# Altium schematic sheet FPGA_POWER_AND_CONFIGURATION.SchDoc
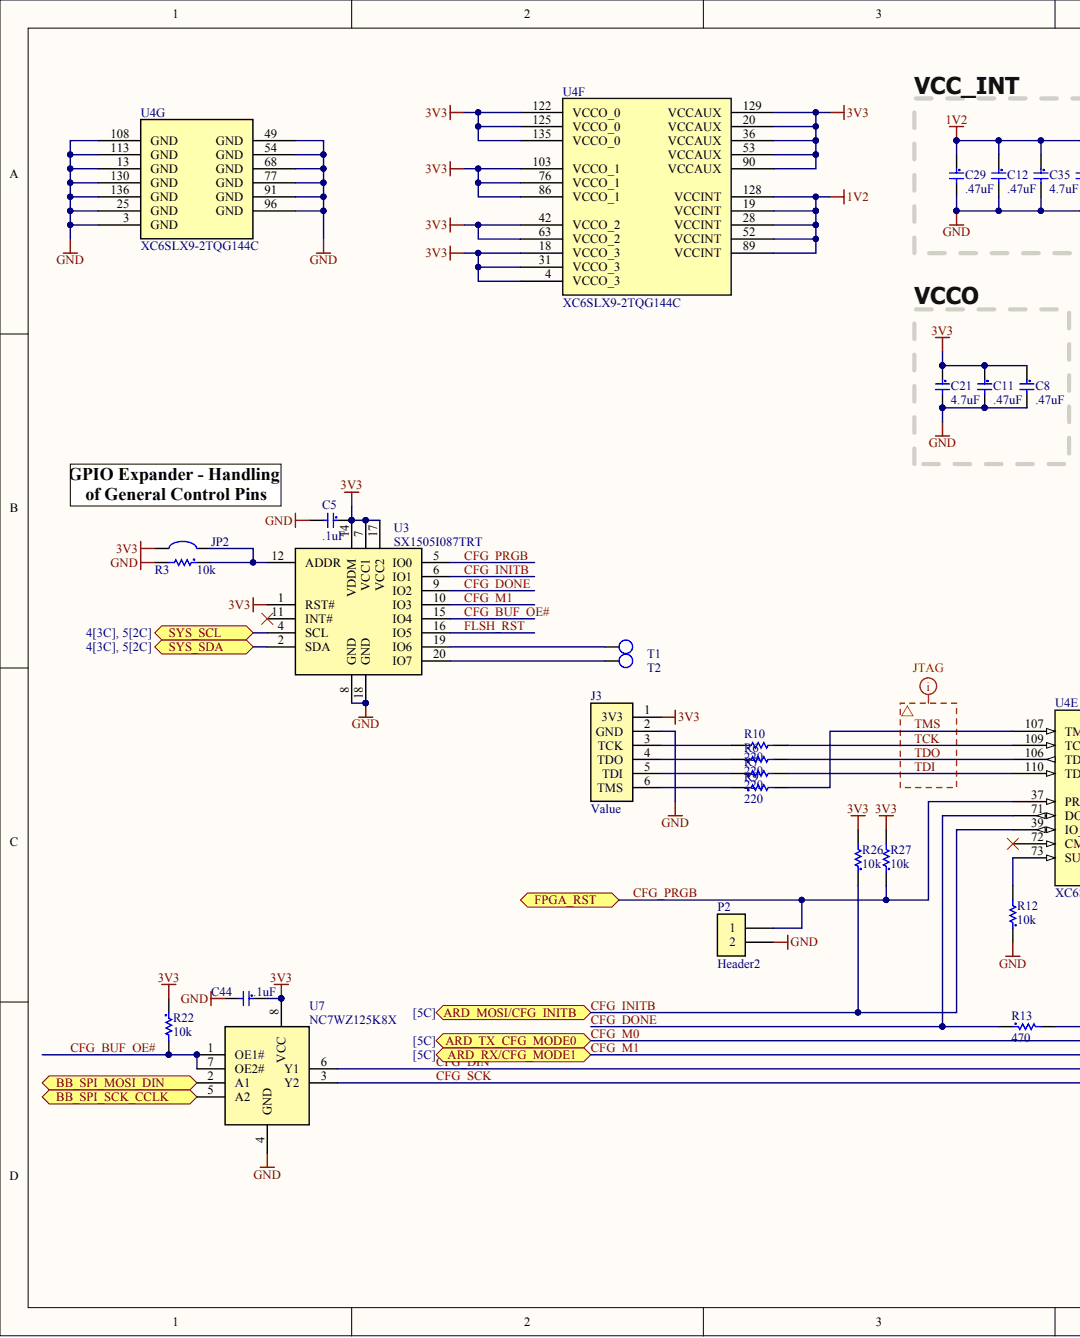
[diagram p0: FPGA_POWER_AND_CONFIGURATION.SchDoc, left]
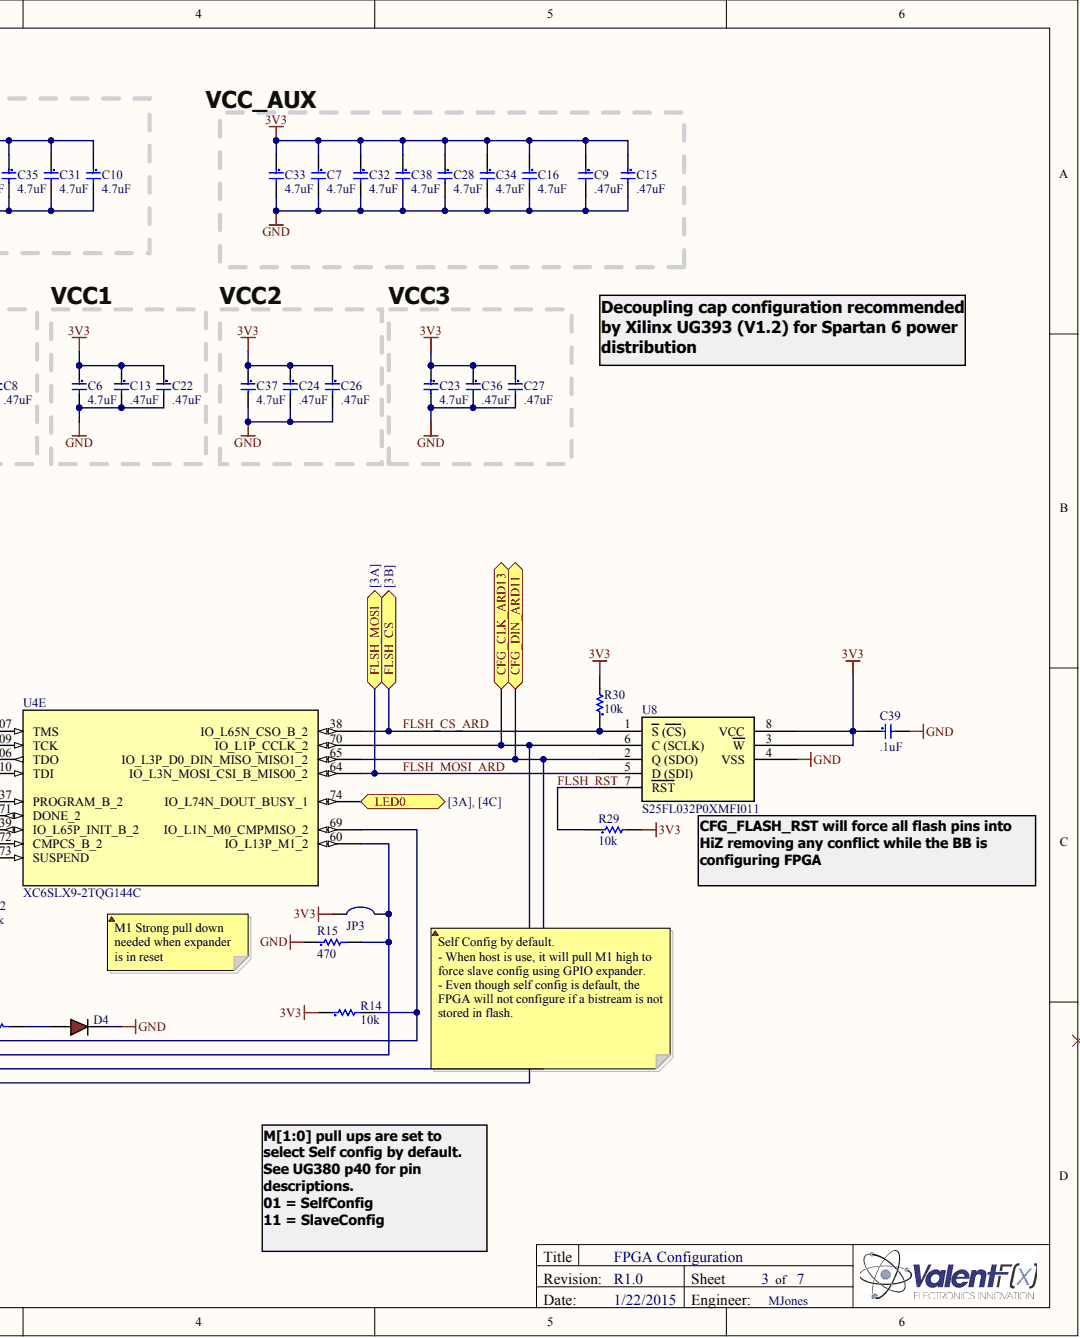
[diagram p1: FPGA_POWER_AND_CONFIGURATION.SchDoc, right]

## Parts
C5  .1uF  [c0603]
C6  4.7uF  [C0603 - Marked]
C7  4.7uF  [C0603 - Marked]
C8  .47uF  [C0603]
C9  .47uF  [C0603]
C10  4.7uF  [C0603 - Marked]
C11  .47uF  [C0603]
C12  .47uF  [C0603]
C13  .47uF  [C0603]
C15  .47uF  [C0603]
C16  4.7uF  [C0603 - Marked]
C21  4.7uF  [C0603 - Marked]
C22  .47uF  [C0603]
C23  4.7uF  [C0603 - Marked]
C24  .47uF  [C0603]
C26  .47uF  [C0603]
C27  .47uF  [C0603]
C28  4.7uF  [C0603 - Marked]
C29  .47uF  [C0603]
C31  4.7uF  [C0603 - Marked]
C32  4.7uF  [C0603 - Marked]
C33  4.7uF  [C0603 - Marked]
C34  4.7uF  [C0603 - Marked]
C35  4.7uF  [C0603 - Marked]
C36  .47uF  [C0603]
C37  4.7uF  [C0603 - Marked]
C38  4.7uF  [C0603 - Marked]
C39  .1uF  [c0603]
C44  .1uF  [c0603]
D4  598-8010-107F  [LED_0603 - RED]  (value not drawn)
J3  Value  [JTAG - Digilent HS1 RA]  (no BOM)
JP2  Solder Jumper  [SOLDER_JUMPER]  (value not drawn)
JP3  Solder Jumper  [SOLDER_JUMPER]  (value not drawn)  (no BOM)
P2  Header2  [HEADER_2_40_100 THRU SIP]  (no BOM)
R3  10k  [R0603]
R6  220  [R0603]
R7  220  [R0603]
R9  220  [R0603]
R10  220  [R0603]
R12  10k  [R0603]
R13  470  [R0603]
R14  10k  [R0603]
R15  470  [R0603]
R22  10k  [R0603]
R26  10k  [R0603]
R27  10k  [R0603]
R29  10k  [R0603]
R30  10k  [R0603]
T1  Test Point  [Tp 13-27]  (value not drawn)  (no BOM)
T2  Test Point  [Tp 13-27]  (value not drawn)  (no BOM)
U3  SX1505I087TRT  [SX1504 - QFN40P300X300X2_HS-21L]
U4  XC6SLX9-2TQG144C  [TQ144_L]  (parts E,F,G)
U7  NC7WZ125K8X  [NC7WZ125K8X - TSSOP50P310X80-8L]
U8  S25FL032P0XMFI011  [S25FL032P0XMFI011 - SOIC127P800X216-8L]

## Ports
ARD_MOSI/CFG_INITB, ARD_RX/CFG_MODE1, ARD_TX_CFG_MODE0, BB_SPI_MOSI_DIN, BB_SPI_SCK_CCLK, CFG_CLK_ARD13, CFG_DIN_ARD11, FLSH_CS, FLSH_MOSI, FPGA_RST, LED0, SYS_SCL, SYS_SDA

## Nets
1V2: C10.1 C12.1 C29.1 C31.1 C35.1 U4.19(VCCINT) U4.28(VCCINT) U4.52(VCCINT) U4.89(VCCINT) U4.128(VCCINT)
3V3: C5.1 C6.1 C7.1 C8.1 C9.1 C11.1 C13.1 C15.1 C16.1 C21.1 C22.1 C23.1 C24.1 C26.1 C27.1 C28.1 C32.1 C33.1 C34.1 C36.1 C37.1 C38.1 C39.1 C44.1 J3.1(3V3) JP2.2 JP3.2 R14.1 R22.1 R26.2 R27.2 R29.2 R30.2 U3.1(RST#) U3.7(VCC1) U3.14(VDDM) U3.17(VCC2) U4.4(VCCO_3) U4.18(VCCO_3) U4.20(VCCAUX) U4.31(VCCO_3) U4.36(VCCAUX) U4.42(VCCO_2) U4.53(VCCAUX) U4.63(VCCO_2) U4.76(VCCO_1) U4.86(VCCO_1) U4.90(VCCAUX) U4.103(VCCO_1) U4.122(VCCO_0) U4.125(VCCO_0) U4.129(VCCAUX) U4.135(VCCO_0) U7.8(VCC) U8.3(W) U8.8(VCC)
GND: C5.2 C6.2 C7.2 C8.2 C9.2 C10.2 C11.2 C12.2 C13.2 C15.2 C16.2 C21.2 C22.2 C23.2 C24.2 C26.2 C27.2 C28.2 C29.2 C31.2 C32.2 C33.2 C34.2 C35.2 C36.2 C37.2 C38.2 C39.2 C44.2 D4.C J3.2(GND) P2.2 R3.2 R12.1 R15.1 U3.8(GND) U3.18(GND) U4.3(GND) U4.13(GND) U4.25(GND) U4.49(GND) U4.54(GND) U4.68(GND) U4.77(GND) U4.91(GND) U4.96(GND) U4.108(GND) U4.113(GND) U4.130(GND) U4.136(GND) U7.4(GND) U8.4(VSS)
BB_SPI_MOSI_DIN: U7.2(A1) ; port BB_SPI_MOSI_DIN
BB_SPI_SCK_CCLK: U7.5(A2) ; port BB_SPI_SCK_CCLK
CFG_BUF_OE#: R22.2 U3.15(IO4) U7.1(OE1#) U7.7(OE2#)
CFG_DIN: U4.65(IO_L3P_D0_DIN_MISO_MISO1_2) U7.6(Y1) U8.2(Q_(SDO)) ; port CFG_DIN_ARD11
CFG_DONE: R13.1 U3.9(IO2) U4.71(DONE_2)
CFG_INITB: R26.1 U3.6(IO1) U4.39(IO_L65P_INIT_B_2) ; port ARD_MOSI/CFG_INITB
CFG_M0: R14.2 U4.69(IO_L1N_M0_CMPMISO_2) ; port ARD_TX_CFG_MODE0
CFG_M1: JP3.1 R15.2 U3.10(IO3) U4.60(IO_L13P_M1_2) ; port ARD_RX/CFG_MODE1
CFG_PRGB: P2.1 R27.1 U3.5(IO0) U4.37(PROGRAM_B_2) ; port FPGA_RST
CFG_SCK: U4.70(IO_L1P_CCLK_2) U7.3(Y2) U8.6(C_(SCLK)) ; port CFG_CLK_ARD13
FLSH_CS_ARD: R30.1 U4.38(IO_L65N_CSO_B_2) U8.1(S_(CS)) ; port FLSH_CS
FLSH_MOSI_ARD: U4.64(IO_L3N_MOSI_CSI_B_MISO0_2) U8.5(D_(SDI)) ; port FLSH_MOSI
FLSH_RST: R29.1 U3.16(IO5) U8.7(RST)
LED0: U4.74(IO_L74N_DOUT_BUSY_1) ; port LED0
SYS_SCL: U3.4(SCL) ; port SYS_SCL
SYS_SDA: U3.2(SDA) ; port SYS_SDA
TCK: R10.2 U4.109(TCK)
TDI: R7.2 U4.110(TDI)
TDO: R6.2 U4.106(TDO)
TMS: R9.2 U4.107(TMS)
NetD4_A: D4.A R13.2
NetJ3_3: J3.3(TCK) R10.1
NetJ3_4: J3.4(TDO) R6.1
NetJ3_5: J3.5(TDI) R7.1
NetJ3_6: J3.6(TMS) R9.1
NetJP2_1: JP2.1 R3.1 U3.12(ADDR)
NetR12_2: R12.2 U4.73(SUSPEND)
NetT1_1: T1.1 U3.19(IO6)
NetT2_1: T2.1 U3.20(IO7)
N00130:

Not connected: U3 11(INT#); U4 72(CMPCS_B_2)

## Library data of the parts
C5 C39 C44 = C0603 - CL10F104ZA8NNNC: CAP CER 0.1UF 25V Y5V 0603; Manufacturer: Samsung Electro-Mechanics America, Inc; Accept Alternative: Yes; Capacitance: 0.1µF; Temperature Coefficient: Y5V (F); Tolerance: -20%, +80%; Accept Compatible Replacement Part: Yes; Applications: General Purpose; Category: Capacitors; Component Kind: Standard; ComponentLink1Description: http://www.samsungsem.com/servlet/FileDownload?type=spec&file=CL10F104ZA8NNNC.pdf; ComponentLink1URL: http://www.samsungsem.com/servlet/FileDownload?type=spec&file=CL10F104ZA8NNNC.pdf; Features: -; Height - Seated (Max): -; Lead Spacing: -; Lead Style: -; Library Name: mCapacitors.SchLib; Manufacturer Part Number: CL10F104ZA8NNNC; Mounting Type: Surface Mount, MLCC; Operating Temperature: -30°C ~ 85°C; Package / Case: 0603 (1608 Metric); Packaging: Cut Tape (CT); Pricing 1: 1=0.1, 10=0.017, 25=0.012, 50=0.009, 100=0.0076, 250=0.00624, 500=0.00536, 1000=0.00417 (USD); Ratings: -; RoHS: RoHS Compliant; Size / Dimension: 0.063" L x 0.031" W (1.60mm x 0.80mm); Stock 1: 400907; Supplier 1: Digi-Key; Supplier Part Number 1: 1276-1011-1-ND; Thickness (Max): 0.035" (0.90mm); Value: .1uF; Voltage - Rated: 25V
C6 C7 C10 C16 C21 C23 C28 C31-C35 C37 C38 = C0603 - GRM188R60J475KE19D: 4.7uF 6.3volts X5R 10% (MLCC) - SMD/SMT 0603; Accept Compatible Replacement Part: Yes; Manufacturer: Murata Electronics North America; Capacitance: 4.7µF; Voltage - Rated: 6.3V; Applications: General Purpose; Category: Capacitors; Component Kind: Standard; ComponentLink1Description: http://search.murata.co.jp/Ceramy/image/img/PDF/ENG/GRM188R60J475KE19.pdf; ComponentLink1URL: http://search.murata.co.jp/Ceramy/image/img/PDF/ENG/GRM188R60J475KE19.pdf; Features: -; Height - Seated (Max): -; Lead Spacing: -; Lead Style: -; Library Name: mCapacitors.SchLib; Manufacturer Part Number: GRM188R60J475KE19D; Mounting Type: Surface Mount, MLCC; Operating Temperature: -55°C ~ 85°C; Package / Case: 0603 (1608 Metric); Packaging: Cut Tape (CT); Pricing 1: 1=0.19, 10=0.132, 50=0.076, 100=0.0627, 250=0.05284, 500=0.04426, 1000=0.03633 (USD); Ratings: -; RoHS: RoHS Compliant; Size / Dimension: 0.063" L x 0.031" W (1.60mm x 0.80mm); Stock 1: 614848; Supplier 1: Digi-Key; Supplier Part Number 1: 490-3297-1-ND; Temperature Coefficient: X5R; Thickness (Max): 0.035" (0.90mm); Tolerance: ±10%; Value: 4.7uF
C8 C9 C11-C13 C15 C22 C24 C26 C27 C29 C36 = C0603 - GCM188R71E474KA64D: .47uF 25VDC X7R 10% SMD 0603 Paper T/R CERAMIC; Accept Compatible Replacement Part: Yes; Manufacturer: Murata Electronics North America; Capacitance: 0.47µF; Voltage - Rated: 25V; Applications: Automotive; Category: Capacitors; Component Kind: Standard; ComponentLink1Description: http://search.murata.co.jp/Ceramy/image/img/PDF/ENG/GCM188R71E474KA64.pdf; ComponentLink1URL: http://search.murata.co.jp/Ceramy/image/img/PDF/ENG/GCM188R71E474KA64.pdf; Features: -; Height - Seated (Max): -; Lead Spacing: -; Lead Style: -; Library Name: mCapacitors.SchLib; Manufacturer Part Number: GCM188R71E474KA64D; Mounting Type: Surface Mount, MLCC; Operating Temperature: -55°C ~ 125°C; Package / Case: 0603 (1608 Metric); Packaging: Cut Tape (CT); Pricing 1: 1=0.26, 10=0.214, 50=0.1202, 100=0.1068, 250=0.08012, 500=0.07076, 1000=0.06275 (USD); Ratings: AEC-Q200; RoHS: RoHS Compliant; Size / Dimension: 0.063" L x 0.031" W (1.60mm x 0.80mm); Stock 1: 100000; Supplier 1: Digi-Key; Supplier Part Number 1: 490-4776-1-ND; Temperature Coefficient: X7R; Thickness (Max): 0.035" (0.90mm); Tolerance: ±10%; Value: .47uF
D4 = LED0603 - 598-8010-107F: LED ALINGAP RED CLEAR 0603 SMD; Manufacturer: Dialight; Accept Replacement: YES - Water Clear; Current - Test: 20mA; Lens Style/Size: Rectangle with Flat Top, 1.1mm x 0.8mm; Millicandela Rating: 40mcd; Viewing Angle: 140°; Voltage - Forward (Vf) Typ: 2.2V; Wavelength - Dominant: 635nm; Category: Optoelectronics; Color: Red; Component Kind: Standard; ComponentLink1Description: http://media.digikey.com/pdf/Data%20Sheets/Dialight%20PDFs/598_Series_0603_Pkg.pdf; ComponentLink1URL: http://media.digikey.com/pdf/Data%20Sheets/Dialight%20PDFs/598_Series_0603_Pkg.pdf; Height: 0.70mm; Lens Type: Clear; Library Name: mDiode.SchLib; Luminous Flux @ Current - Test: -; Manufacturer Part Number: 598-8010-107F; Mounting Type: Surface Mount; Package / Case: 0603 (1608 Metric); Packaging: Digi-Reel®; Pricing 1: 1=0.45, 10=0.33, 25=0.264, 100=0.1749, 250=0.1452, 500=0.1056, 1000=0.0825 (USD); RoHS: RoHS Compliant; Size / Dimension: 1.60mm L x 0.80mm W; Stock 1: 37578; Supplier 1: Digi-Key; Supplier Part Number 1: 350-2029-6-ND; Wavelength - Peak: -
J3 = JTAG - Digilent HS1: DIGILENT - 410-205 - PROGRAMMING CABLE, JTAG HS1, USB2; Manufacturer: Samtec; Manufacturer Part Number: TSW-106-08-G-S-RA; Connector Type: Header, Unshrouded; Lead Time: 0 weeks; MSL (Moisture Sensitivity Level): N/R; Number of Positions: 6; Number of Positions Loaded: All; Peak Solder Temperature: 260 deg C; Pitch: 0.100" (2.54mm); Category: Connectors, Interconnects; China ROHS Cadmium (X -> above / O -> below threshold): O; China ROHS Hex Chromium (X -> above / O -> below threshold): O; China ROHS Lead (X -> above / O -> below threshold): O; China ROHS Mercury (X -> above / O -> below threshold): O; China ROHS PBB (X -> above / O -> below threshold): O; China ROHS PBDE (X -> above / O -> below threshold): O; Color: Black; ComponentLink1Description: http://components.arrow.com/partservice/dataview/altium/2677508S3639200N1004?apiKey=aa438727d59eed98c4bed826d8d949c84cb5e685b92bf87346a0226bd2658797; ComponentLink1URL: http://components.arrow.com/partservice/dataview/altium/2677508S3639200N1004?apiKey=aa438727d59eed98c4bed826d8d949c84cb5e685b92bf87346a0226bd2658797; ComponentLink2Description: http://www.samtec.com/documents/webfiles/cpdf/TSW-XXX-XX-XXX-X-XX-XXX-MKT.pdf; ComponentLink2URL: http://www.samtec.com/documents/webfiles/cpdf/TSW-XXX-XX-XXX-X-XX-XXX-MKT.pdf; Contact Finish: Gold; Contact Finish Thickness: 10µin (0.25µm); Contact Mating Length: 0.230" (5.84mm); Contact Type: Male Pin; EU_ROHS_PROD_EXEMPTION_BY_SUPP: N/R; Fastening Type: -; Features: -; Library Name: mConnector.SchLib; Mounting Type: Through Hole; MSL Level Max: N/R; MSL Level Min: N/R; Number of Rows: 1; Package Quantity: 1; Packaging: Bulk; Pricing 1: 0=0.2649 (USD); Pricing 2: 1=0.94, 10=0.841, 100=0.6926, 500=0.5475, 1000=0.46999, 5000=0.42877, 10000=0.41228 (USD); RoHS: CHINA ROHS: Compliant / EU ROHS: Compliant; Row Spacing: -; Stock 1: 0; Stock 2: 0; Supplier 1: Arrow; Supplier 2: Digi-Key; Supplier Part Number 1: 2677508S3639200N1004; Supplier Part Number 2: SAM1038-06-ND; Termination: Solder; Termination Finish: Au/Ni
JP2 JP3 = Solder Jumper: Solder/Configuration Jumper; Library Name: mConnector.SchLib; Manufacturer: n/a; Manufacturer Part Number: n/a; ROHS Certificate: n/a; Source: n/a; Source Part Number: n/a
  JP2: Component Kind: Net Tie
P2 = Header2: Header 2 pin; Library Name: mConnector.SchLib
R3 R12 R14 R22 R26 R27 R29 R30 = R0603 - CRCW060310K0FKEA: 10k Thick Film Resistors - SMD 1/10watt 10Kohms 1%; Manufacturer: Vishay Dale; Power (Watts): 0.1W, 1/10W; Temperature Coefficient: ±100ppm/°C; Category: Resistors; Component Kind: Standard; ComponentLink1Description: http://www.vishay.com/doc?20035; ComponentLink1URL: http://www.vishay.com/doc?20035; Composition: Thick Film; Features: -; Height: 0.020" (0.50mm); Library Name: mResistors.SchLib; Manufacturer Part Number: CRCW060310K0FKEA; Number of Terminations: 2; Package / Case: 0603 (1608 Metric); Packaging: Cut Tape (CT); Pricing 1: 10=0.081, 50=0.0436, 200=0.0251, 1000=0.01711 (USD); Resistance (Ohms): 10K; RoHS: RoHS Compliant; Size / Dimension: 0.061" L x 0.033" W (1.55mm x 0.85mm); Stock 1: 997856; Supplier 1: Digi-Key; Supplier Part Number 1: 541-10.0KHCT-ND; Tolerance: ±1%; Value: 10k
R6 R7 R9 R10 = R0603 - ERJ-3EKF2200V: 220 - thick Film Resistors - SMD 0603 220ohms 1% Tol; Accept Compatible Replacement Part: Yes; Manufacturer: Panasonic - ECG; Power (Watts): 0.1W, 1/10W; Temperature Coefficient: ±100ppm/°C; Category: Resistors; Component Kind: Standard; ComponentLink1Description: http://industrial.panasonic.com/www-cgi/jvcr13pz.cgi?E+PZ+3+AOA0002+ERJ3EKF2200V+7+WW; ComponentLink1URL: http://industrial.panasonic.com/www-cgi/jvcr13pz.cgi?E+PZ+3+AOA0002+ERJ3EKF2200V+7+WW; Composition: Thick Film; Features: -; Height: 0.022" (0.55mm); Library Name: mResistors.SchLib; Manufacturer Part Number: ERJ-3EKF2200V; Number of Terminations: 2; Package / Case: 0603 (1608 Metric); Packaging: Cut Tape (CT); Pricing 1: 1=0.1, 50=0.0138, 100=0.0105, 250=0.0078, 500=0.00576, 1000=0.00384 (USD); Resistance (Ohms): 220; RoHS: RoHS Compliant; Size / Dimension: 0.063" L x 0.031" W (1.60mm x 0.80mm); Stock 1: 517798; Supplier 1: Digi-Key; Supplier Part Number 1: P220HCT-ND; Tolerance: ±1%; Value: 220
R13 R15 = R0603 - CRCW0603470RJNEA: RES 470 OHM 1/10W 5% 0603 SMD; Manufacturer: Vishay Dale; Power (Watts): 0.1W, 1/10W; Temperature Coefficient: ±200ppm/°C; Category: Resistors; Component Kind: Standard; ComponentLink1Description: http://www.vishay.com/docs/20035/dcrcwe3.pdf; ComponentLink1URL: http://www.vishay.com/docs/20035/dcrcwe3.pdf; Composition: Thick Film; Features: Automotive AEC-Q200 Qualified; Height: 0.020" (0.50mm); Library Name: mResistors.SchLib; Manufacturer Part Number: CRCW0603470RJNEA; Number of Terminations: 2; Operating Temperature: -55°C ~ 155°C; Package / Case: 0603 (1608 Metric); Packaging: Digi-Reel®; Pricing 1: 10=0.08, 50=0.0432, 200=0.0248, 1000=0.01694 (USD); Resistance (Ohms): 470; RoHS: RoHS Compliant; Size / Dimension: 0.061" L x 0.033" W (1.55mm x 0.85mm); Stock 1: 83706; Supplier 1: Digi-Key; Supplier Device Package: 0603 (1608 Metric); Supplier Part Number 1: 541-470GDKR-ND; Tolerance: ±5%
T1 T2 = Test Point 2: Test Point Smaller Symbol; Library Name: mConnector.SchLib
U3 = EXP - SX1505I087TRT: IC GPIO EXP I2C 8CH 20UTQFN; Manufacturer: Semtech; Current - Output Source/Sink: 8mA, 24mA; Frequency - Clock: 400kHz; Interface: I²C; Interrupt Output: Yes; Number of I/O: 8; Output Type: Push-Pull; Voltage - Supply: 2.5 V ~ 5.5 V; Category: Integrated Circuits (ICs); Component Kind: Standard; ComponentLink1Description: http://www.semtech.com/images/datasheet/sx150x_456.pdf; ComponentLink1URL: http://www.semtech.com/images/datasheet/sx150x_456.pdf; Features: POR; Library Name: mIC.SchLib; Manufacturer Part Number: SX1505I087TRT; Mounting Type: Surface Mount; Operating Temperature: -40°C ~ 85°C; Package / Case: 20-UFQFN Exposed Pad; Packaging: Cut Tape (CT); Pricing 1: 1=0.99, 25=0.6652, 100=0.57, 1000=0.532 (USD); RoHS: RoHS Compliant; Stock 1: 4878; Supplier 1: Digi-Key; Supplier Device Package: 20-QFN-UT (3x3); Supplier Part Number 1: SX1505I087CT-ND
U4 = FPGA - XC6SLX9-2TQG144C: IC FPAG SPARTAN 6 9K 144TQFP; Manufacturer: Xilinx Inc; Number of I /O: 102; Number of LABs/CLBs: 715; Number of Logic Elements/Cells: 9152; Total RAM Bits: 589824; Voltage - Supply: 1.14 V ~ 1.26 V; Category: Integrated Circuits (ICs); Component Kind: Standard; ComponentLink1Description: http://www.xilinx.com/support/documentation/data_sheets/ds160.pdf; ComponentLink1URL: http://www.xilinx.com/support/documentation/data_sheets/ds160.pdf; Library Name: mIC.SchLib; Manufacturer Part Number: XC6SLX9-2TQG144C; Mounting Type: Surface Mount; Number of Gates: -; Operating Temperature: 0°C ~ 85°C; Package / Case: 144-LQFP; Pricing 1: 1=12.80,1=11.05,1000=9.59 (USD); RoHS: RoHS Compliant; Stock 1: 994; Supplier 1: America II Electronics; Supplier 2: Digi-Key; Supplier Device Package: 144-TQFP (20x20); Supplier Part Number 1: 122-1745-ND; Value: XC6SLX9-2TQG144C
U7 = BUF - NC7WZ125K8X: IC BUFF TRI-ST DL UHS N-INV US8; Manufacturer: Fairchild Semiconductor; Current - Output High, Low: 32mA, 32mA; Logic Type: Buffer/Line Driver, Non-Inverting; Number of Bits per Element: 1; Number of Elements: 2; Voltage - Supply: 1.65 V ~ 5.5 V; Category: Integrated Circuits (ICs); Component Kind: Standard; ComponentLink1Description: http://www.fairchildsemi.com/datasheets/NC/NC7WZ125.pdf; ComponentLink1URL: http://www.fairchildsemi.com/datasheets/NC/NC7WZ125.pdf; Library Name: mLogic.SchLib; Manufacturer Part Number: NC7WZ125K8X; Mounting Type: Surface Mount; Operating Temperature: -40°C ~ 85°C; Package / Case: 8-VFSOP (0.091", 2.30mm Width); Packaging: Cut Tape (CT); Pricing 1: 1=0.53, 10=0.414, 25=0.3492, 100=0.2845, 250=0.2356, 500=0.19464, 1000=0.14578 (USD); RoHS: RoHS Compliant; Stock 1: 4337; Supplier 1: Digi-Key; Supplier Device Package: US8; Supplier Part Number 1: NC7WZ125K8XCT-ND
U8 = FLSH - S25FL032P0XMFI011: IC FLASH 32MBIT 104MHZ 8SO; Manufacturer: Spansion; Interface: SPI Serial; Memory Size: 32M (4M x 8); Memory Type: FLASH - NOR; Voltage - Supply: 2.7 V ~ 3.6 V; Category: Integrated Circuits (ICs); Component Kind: Standard; ComponentLink1Description: http://www.spansion.com/Support/Datasheets/S25FL032P_00.pdf; ComponentLink1URL: http://www.spansion.com/Support/Datasheets/S25FL032P_00.pdf; Format - Memory: FLASH; Library Name: mMemory.SchLib; Manufacturer Part Number: S25FL032P0XMFI011; Operating Temperature: -40°C ~ 85°C; Package / Case: 8-SOIC (0.209", 5.30mm Width); Packaging: Tube; Pricing 1: 1=0.7, 10=0.639, 50=0.6272, 100=0.5608, 250=0.556, 500=0.54788, 1000=0.52812, 2500=0.51322, 5000=0.48114 (USD); RoHS: RoHS Compliant; Speed: 104MHz; Stock 1: 5871; Supplier 1: Digi-Key; Supplier Device Package: 8-SO; Supplier Part Number 1: S25FL032P0XMFI011-ND
